# Altium schematic sheet Control.SchDoc
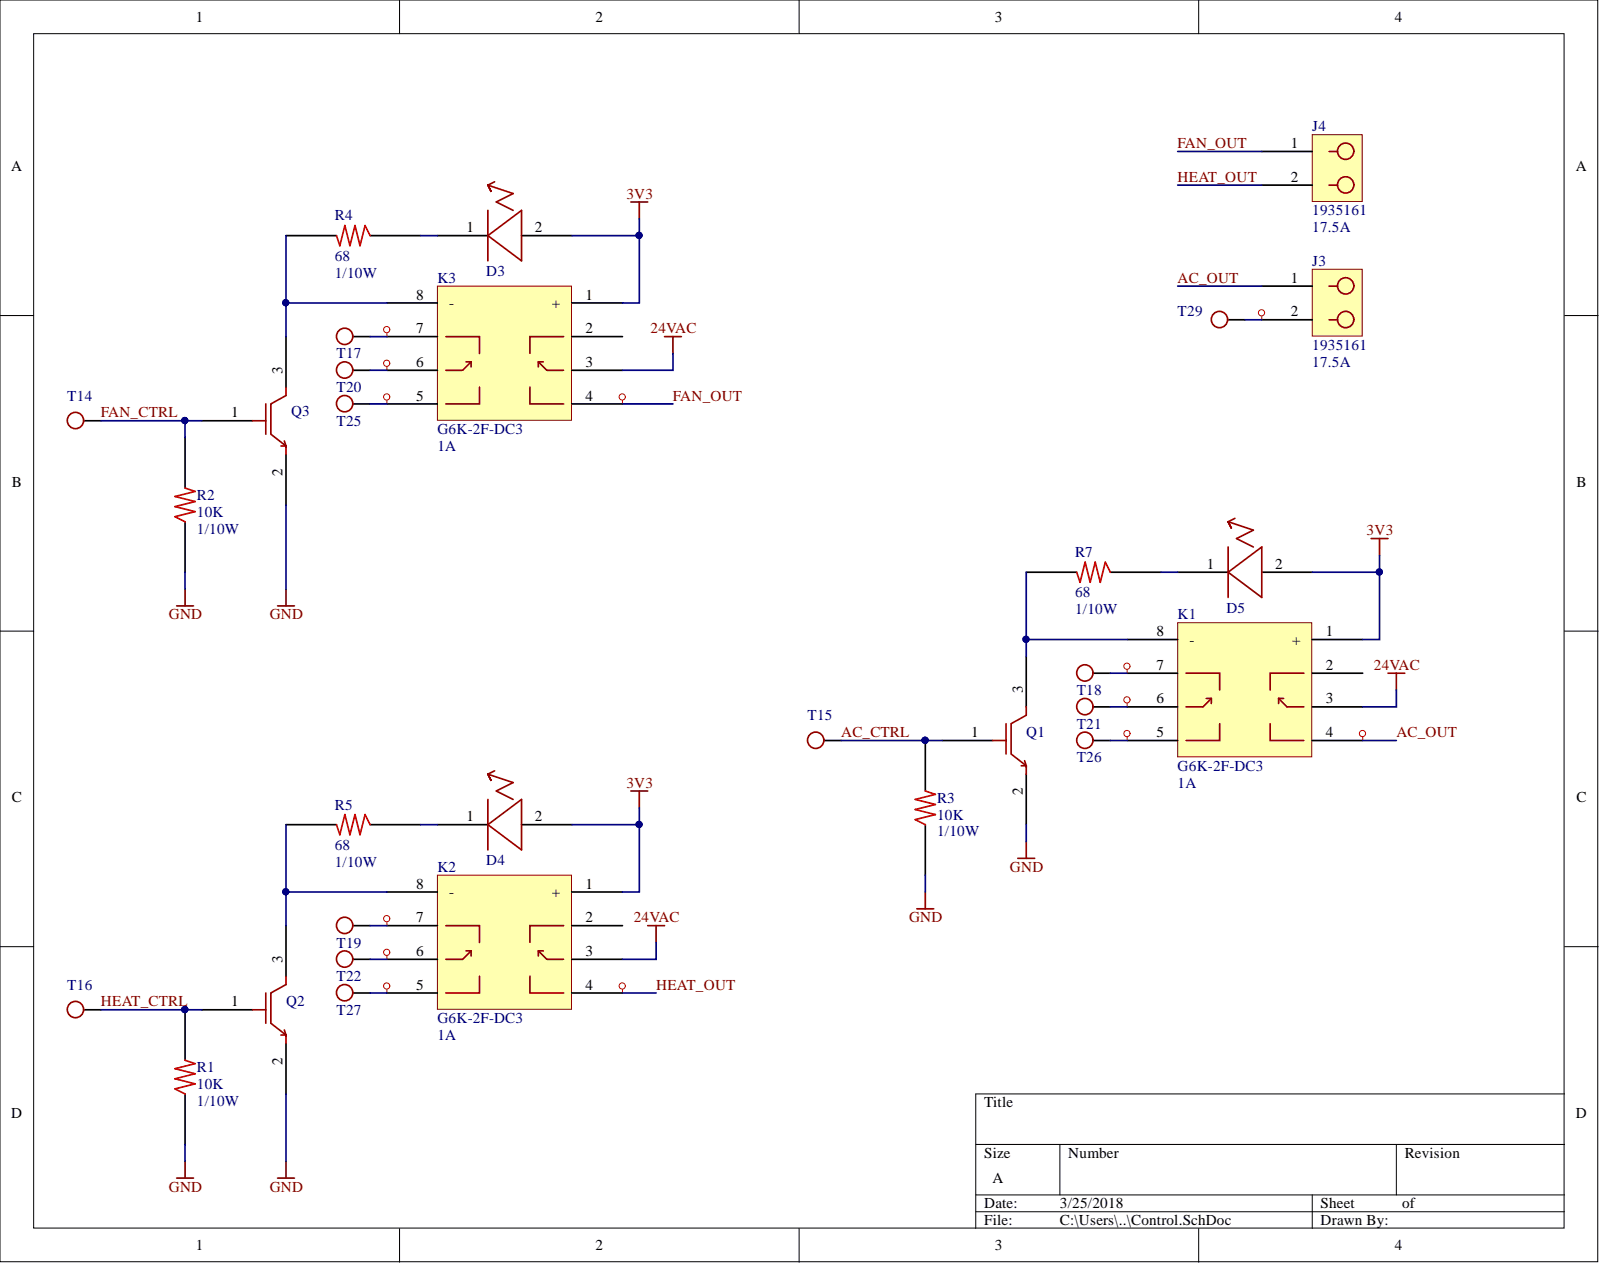
[diagram p0: Control.SchDoc]

## Parts
D3  D_LED_LED5MM  [LED5MM]  (value not drawn)
D4  D_LED_LED5MM  [LED5MM]  (value not drawn)
D5  D_LED_LED5MM  [LED5MM]  (value not drawn)
J3  Rating: 17.5A  [1935161]  (hidden comment: J_2POSTERM_1935161)
J4  Rating: 17.5A  [1935161]  (hidden comment: J_2POSTERM_1935161)
K1  PartNumber: G6K-2F-DC3  Rating: 1A  [G6K-2F]  (hidden comment: K_G6K-2F_G6K-2F)
K2  PartNumber: G6K-2F-DC3  Rating: 1A  [G6K-2F]  (hidden comment: K_G6K-2F_G6K-2F)
K3  PartNumber: G6K-2F-DC3  Rating: 1A  [G6K-2F]  (hidden comment: K_G6K-2F_G6K-2F)
Q1  Q_NFET_SOT23  [SOT23]  (value not drawn)
Q2  Q_NFET_SOT23  [SOT23]  (value not drawn)
Q3  Q_NFET_SOT23  [SOT23]  (value not drawn)
R1  value 10K  Rating: 1/10W  [0603]  (hidden comment: R_RES_0603)
R2  value 10K  Rating: 1/10W  [0603]  (hidden comment: R_RES_0603)
R3  value 10K  Rating: 1/10W  [0603]  (hidden comment: R_RES_0603)
R4  value 68  [0603]  (hidden comment: R_RES_0603)
R5  value 68  [0603]  (hidden comment: R_RES_0603)
R7  value 68  [0603]  (hidden comment: R_RES_0603)
T14  TESTPOINT  [TESTPOINT1MM]  (value not drawn)
T15  TESTPOINT  [TESTPOINT1MM]  (value not drawn)
T16  TESTPOINT  [TESTPOINT1MM]  (value not drawn)
T17  TESTPOINT  [TESTPOINT1MM]  (value not drawn)
T18  TESTPOINT  [TESTPOINT1MM]  (value not drawn)
T19  TESTPOINT  [TESTPOINT1MM]  (value not drawn)
T20  TESTPOINT  [TESTPOINT1MM]  (value not drawn)
T21  TESTPOINT  [TESTPOINT1MM]  (value not drawn)
T22  TESTPOINT  [TESTPOINT1MM]  (value not drawn)
T25  TESTPOINT  [TESTPOINT1MM]  (value not drawn)
T26  TESTPOINT  [TESTPOINT1MM]  (value not drawn)
T27  TESTPOINT  [TESTPOINT1MM]  (value not drawn)
T29  TESTPOINT  [TESTPOINT1MM]  (value not drawn)

## Nets
3V3: D3.2 D4.2 D5.2 K1.1(+) K2.1(+) K3.1(+)
24VAC: K1.3 K2.3 K3.3
GND: Q1.2 Q2.2 Q3.2 R1.1 R2.1 R3.1
AC_CTRL: Q1.1 R3.2 T15.1
AC_OUT: J3.1 K1.4
FAN_CTRL: Q3.1 R2.2 T14.1
FAN_OUT: J4.1 K3.4
HEAT_CTRL: Q2.1 R1.2 T16.1
HEAT_OUT: J4.2 K2.4
NetD3_1: D3.1 R4.1
NetD4_1: D4.1 R5.1
NetD5_1: D5.1 R7.1
NetJ3_2: J3.2 T29.1
NetK1_5: K1.5 T26.1
NetK1_6: K1.6 T21.1
NetK1_7: K1.7 T18.1
NetK1_8: K1.8(-) Q1.3 R7.2
NetK2_5: K2.5 T27.1
NetK2_6: K2.6 T22.1
NetK2_7: K2.7 T19.1
NetK2_8: K2.8(-) Q2.3 R5.2
NetK3_5: K3.5 T25.1
NetK3_6: K3.6 T20.1
NetK3_7: K3.7 T17.1
NetK3_8: K3.8(-) Q3.3 R4.2

Not connected: K1 2; K2 2; K3 2

## Library data of the parts
J3 J4 = J_2POSTERM_1935161: Manufacturer: Phoenix Contact; Component Kind: Standard; Library Name: Hub.SchLib; PartNumber: 1935161
K1-K3 = K_G6K-2F_G6K-2F: Manufacturer: Omron; Component Kind: Standard; Library Name: Hub.SchLib
Q1-Q3 = Q_NFET_SOT23: Manufacturer: On Semiconductor; PartNumber: BSS138; Rating: 220mA; Component Kind: Standard; Library Name: Hub.SchLib
R1-R3 = R_RES_0603: Manufacturer: Yageo; PartNumber: RC0603JR-0710KL; Component Kind: Standard; Library Name: SeniorProject.SchLib
R4 R5 R7 = R_RES_0603: Manufacturer: Yageo; PartNumber: RC0603FR-0768RL; Rating: 1/10W; Component Kind: Standard; Library Name: SeniorProject.SchLib
T14-T22 T25-T27 T29 = T_TESTPOINT_TESTPOINT1MM: Value: 1mm; Component Kind: Standard; Library Name: Hub.SchLib
D3-D5 = D_LED_LED5MM: Component Kind: Standard; Library Name: Hub.SchLib
